# Altium schematic sheet I2C_Repeater.SchDoc
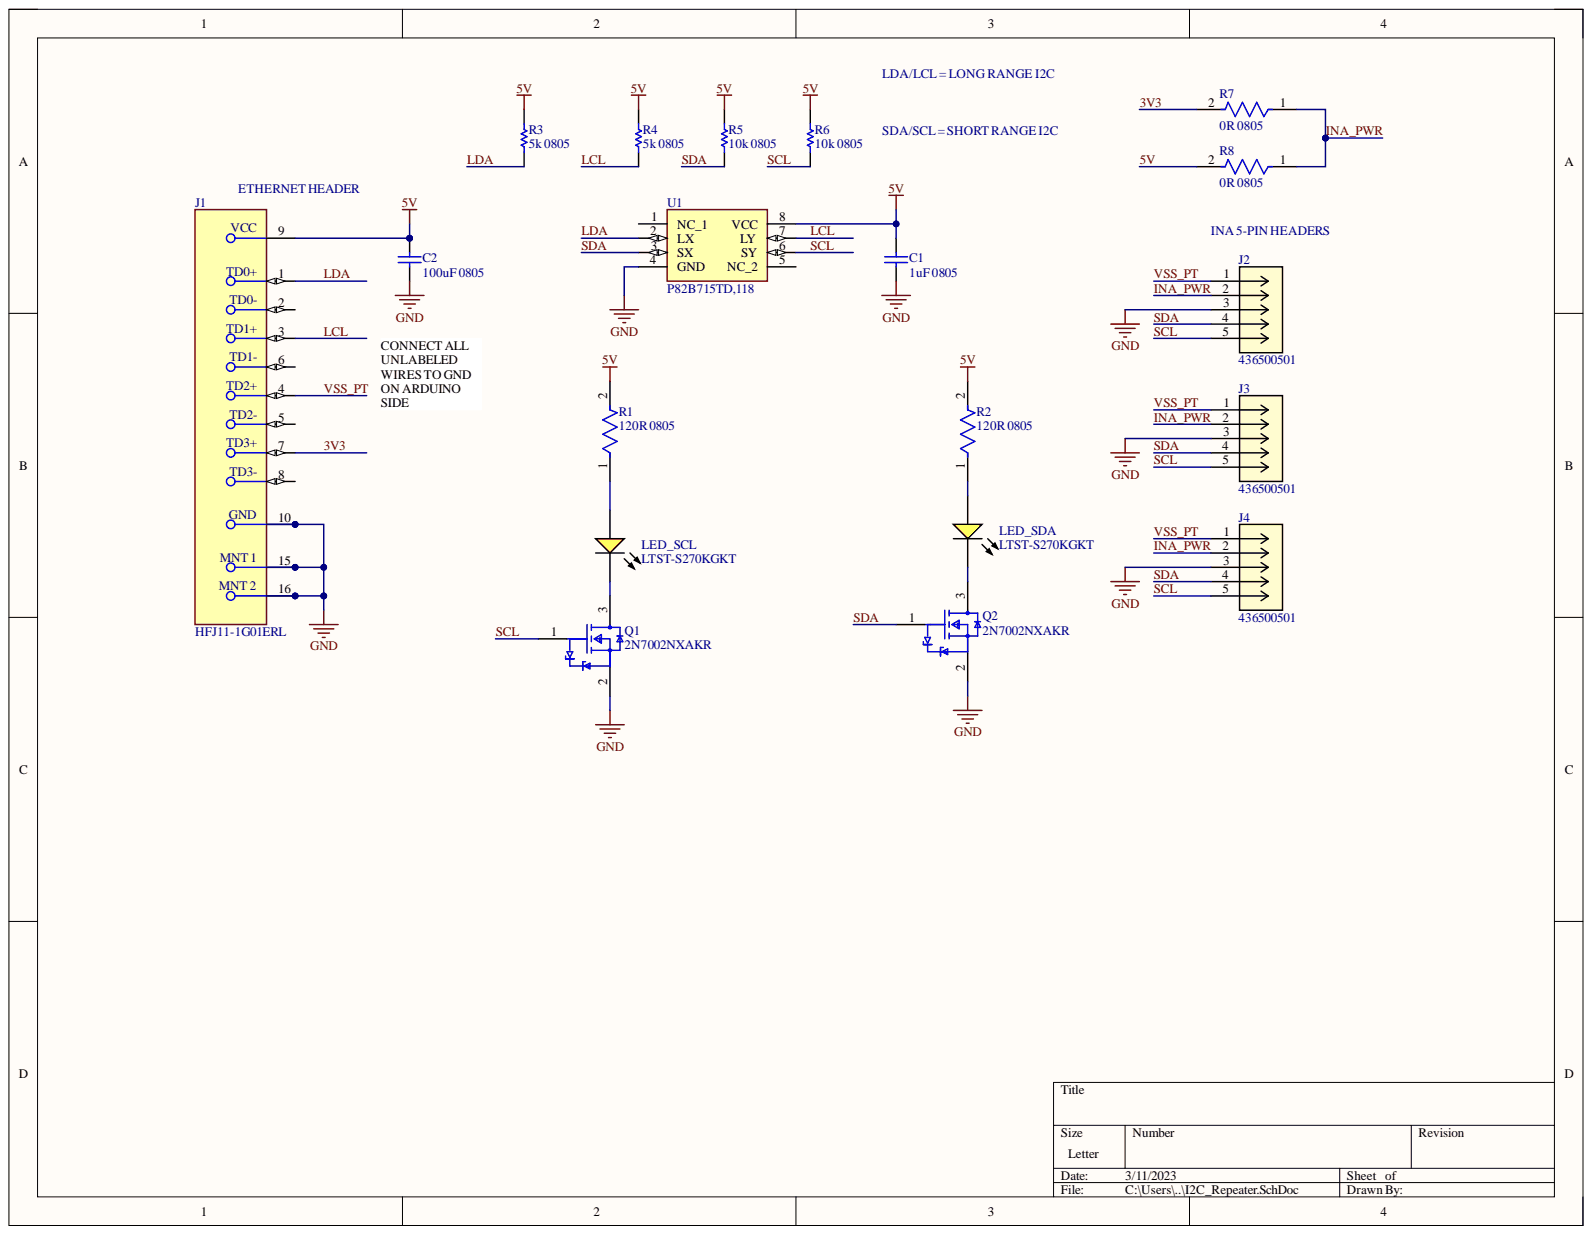
[diagram p0: I2C_Repeater.SchDoc]

## Parts
C1  1uF 0805  [CAPC2013X135X45LL10T25]
C2  100uF 0805  [CAPC2013X135X45LL10T25]
J1  HFJ11-1G01ERL  [FP-HFJ11-1G01ERL-MFG]
J2  436500501  [CON_436500501_MOL]
J3  436500501  [CON_436500501_MOL]
J4  436500501  [CON_436500501_MOL]
LED_SCL  LTST-S270KGKT  [AVAG-HSMX-C190_V]
LED_SDA  LTST-S270KGKT  [AVAG-HSMX-C190_V]
Q1  2N7002NXAKR  [FP-SOT23-IPC_B]
Q2  2N7002NXAKR  [FP-SOT23-IPC_B]
R1  120R 0805  Resistance: 120R  [FP-CRCW0805-e3-IPC_C]
R2  120R 0805  Resistance: 120R  [FP-CRCW0805-e3-IPC_C]
R3  5k 0805  [RESC2013X50X30ML20T20]
R4  5k 0805  [RESC2013X50X30ML20T20]
R5  10k 0805  [RESC2013X50X30ML20T20]
R6  10k 0805  [RESC2013X50X30ML20T20]
R7  0R 0805  Case Code (Imperial): 0805  [FP-CRCW0805-e3-IPC_B]
R8  0R 0805  Case Code (Imperial): 0805  [FP-CRCW0805-e3-IPC_B]
U1  P82B715TD,118  [SOIC127P600X175-8N]

## Nets
GND: C1.1 C2.1 J1.10 J1.15 J1.16 J2.3 J3.3 J4.3 Q1.2 Q2.2 U1.4(GND)
3V3: J1.7 R7.2
5V: C1.2 C2.2 J1.9 R1.2 R2.2 R3.2 R4.2 R5.2 R6.2 R8.2 U1.8(VCC)
INA_PWR: J2.2 J3.2 J4.2 R7.1 R8.1
LCL: J1.3 R4.1 U1.7(LY)
LDA: J1.1 R3.1 U1.2(LX)
SCL: J2.5 J3.5 J4.5 Q1.1 R6.1 U1.6(SY)
SDA: J2.4 J3.4 J4.4 Q2.1 R5.1 U1.3(SX)
VSS_PT: J1.4 J2.1 J3.1 J4.1
NetLED_SCL_1: LED_SCL.1 R1.1
NetLED_SCL_2: LED_SCL.2 Q1.3
NetLED_SDA_1: LED_SDA.1 R2.1
NetLED_SDA_2: LED_SDA.2 Q2.3

Not connected: J1 2 5 6 8; U1 1(NC_1) 5(NC_2)

## Library data of the parts
C1 C2 = CMP-1036-04761-2: Manufacturer: Murata; Part Number: GRM21BR71H105KA12L; Component Kind: Standard; Library Name: Altium Content Vault
J1 = CMP-218039-000001-2: Conn RJ-45 F 8 POS 2.54mm Solder RA Thru-Hole 10 Terminal 1 Port; Manufacturer: HALO; Conn Gender: Female; Conn Orientation: Horizontal; Is Female: yes; Number Of Contacts: 8; Pitch: 1.27mm; Shielding: true; Automotive: No; Category: Conn; Component Kind: Standard; ComponentLink2Description: Datasheet; ComponentLink2URL: https://www.haloelectronics.com/pdf/fastjack-gigabit.pdf; Contact Material: Gold; Device Class L1: Connectors; Device Class L2: Modular / Ethernet Connectors; Device Class L3: unset; Height: 0.624inch; Lead Free: Yes; Library Name: Altium Content Vault; Manufacturer Part Number: HFJ11-1G01ERL; Mouser Description: Conn RJ-45 F 8 POS 2.54mm Solder RA Thru-Hole 10 Terminal 1 Port; Octopart: https://octopart.com/hfj11-1g01erl-halo-4052130; Package: 0; RoHS: TRUE; Temperature: 0°C to +70°C; Temperature Range High: +70°C; Temperature Range Low: 0°C
J2-J4 = 436500501: No Description Available; Mfr_Name: Molex Connector Corporation; Component Kind: Standard; Library Name: 436500501.SchLib; Manufacturer_Part_Number: 436500501; RefDes: RefDes; Type: DEV; Copyright: Copyright (C) 2023 Ultra Librarian. All rights reserved.
LED_SCL LED_SDA = CMP-1744-00003-1: LED Uni-Color Yellow, 65 mW, 20 mA, -40 to 85 degC, 2-Pin SMD, RoHS, Tape and Reel; Case\Package: 0603; Power Rating: 100 mW; Resistance: 390 Ohm; Tolerance: 1%; Voltage Rating: 50 V; Case Code (Imperial): 0603; Case Code (Metric): 1608; Component Kind: Standard; ComponentLink1Description: Manufacturer URL; ComponentLink1URL: http://www.avagotech.com/; ComponentLink2Description: Datasheet; ComponentLink2URL: http://docs.avagotech.com/docs/AV02-0551EN; ComponentLink3Description: Package Specification; ComponentLink3URL: http://www.fairchildsemi.com/dwg/MA/MA03D.pdf; DatasheetVersion: Mar-12; Height: 0.45 mm; Length: 1.6 mm; Library Name: Altium Content Vault; Max Operating Temperature: 155 degC; Min Operating Temperature: -55 degC; Mount: Surface Mount; Package Quantity: 5000; PackageDescription: 2-Pin Surface Mount Device, Body 1.6 x 0.8 mm, Height 0.9 mm; PackageReference: HSMX-C190; Packaging: Tape and Reel; Pins: 2; REACH SVHC: No SVHC; RoHSCompliant: true; Voltage Rating (DC): 50 V; Width: 0.8 mm
Q1 Q2 = CMP-14409-000026-2: 2N7002NXAK/SOT23/TO-236AB; Component Kind: Standard; Library Name: Altium Content Vault
R1 R2 = CMP-02407-007854-1: RES Thick Film, 120Ω, 1%, 0.125W, 100ppm/°C, 0805; Max Power Dissipation: 125mW; Voltage Rating: 150V; Case Code (Imperial): 0805; Case Code (Metric): 2012; Case/Package: 0805; Component Kind: Standard; Composition: Thick Film; Contact Plating: Tin; Depth: 1.25mm; Features: Automotive AEC-Q200; Height: 0.45mm; Lead Free: Lead Free; Length: 2mm; Library Name: Altium Content Vault; Max Operating Temperature: 155°C; Military Standard: Not; Min Operating Temperature: -55°C; Mount: Surface Mount; Number of Terminations: 2; Package Quantity: 10; Packaging: Tape and Reel; Power Rating: 125mW; Radiation Hardening: No; Temperature Coefficient: 100ppm/°C; Termination: SMD/SMT; Tolerance: 1%; Voltage Rating (DC): 150V; Weight: 0.000194oz; Width: 1.25mm
R3-R6 = CMP-2001-04239-1: Manufacturer: Vishay Dale; Part Number: CRCW08055K00FKTA; Component Kind: Standard; Library Name: Altium Content Vault
R7 R8 = CMP-2001-04897-2: RES Thick Film, 0Ω, 0.125W, 0805; Max Power Dissipation: 125mW; Resistance: 0mR; Voltage Rating: 150V; Case Code (Metric): 2012; Case/Package: 0805; Component Kind: Standard; Composition: Thick Film; Contact Plating: Tin; Depth: 1.25mm; Features: Automotive AEC-Q200; Height: 0.02inch; Lead Free: Lead Free; Length: 2mm; Library Name: Altium Content Vault; Max Operating Temperature: 155°C; Military Standard: Not; Min Operating Temperature: -55°C; Number of Terminations: 2; Package Quantity: 10000; Packaging: Tape and Reel; Power Rating: 125mW; Radiation Hardening: No; Termination: SMD/SMT; Voltage Rating (DC): 150V; Weight: 0.000194oz; Width: 0.049inch
U1 = P82B715TD,118: Undefined or Miscellaneous; Manufacturer_Name: NXP; Arrow Part Number: P82B715TD,118; Arrow Price/Stock: https://www.arrow.com/en/products/p82b715td118/nxp-semiconductors?region=nac; Component Kind: Standard; Datasheet Link: http://www.nxp.com/docs/en/data-sheet/P82B715.pdf; Height: 1.75mm; Manufacturer_Part_Number: P82B715TD,118; Mouser Part Number: 771-P82B715TD118; Mouser Price/Stock: https://www.mouser.co.uk/ProductDetail/NXP-Semiconductors/P82B715TD118?qs=LOCUfHb8d9tYdoWkpYDXXg%3D%3D
